# Altium schematic sheet NVC_Conn.SchDoc(NVC_Conn)
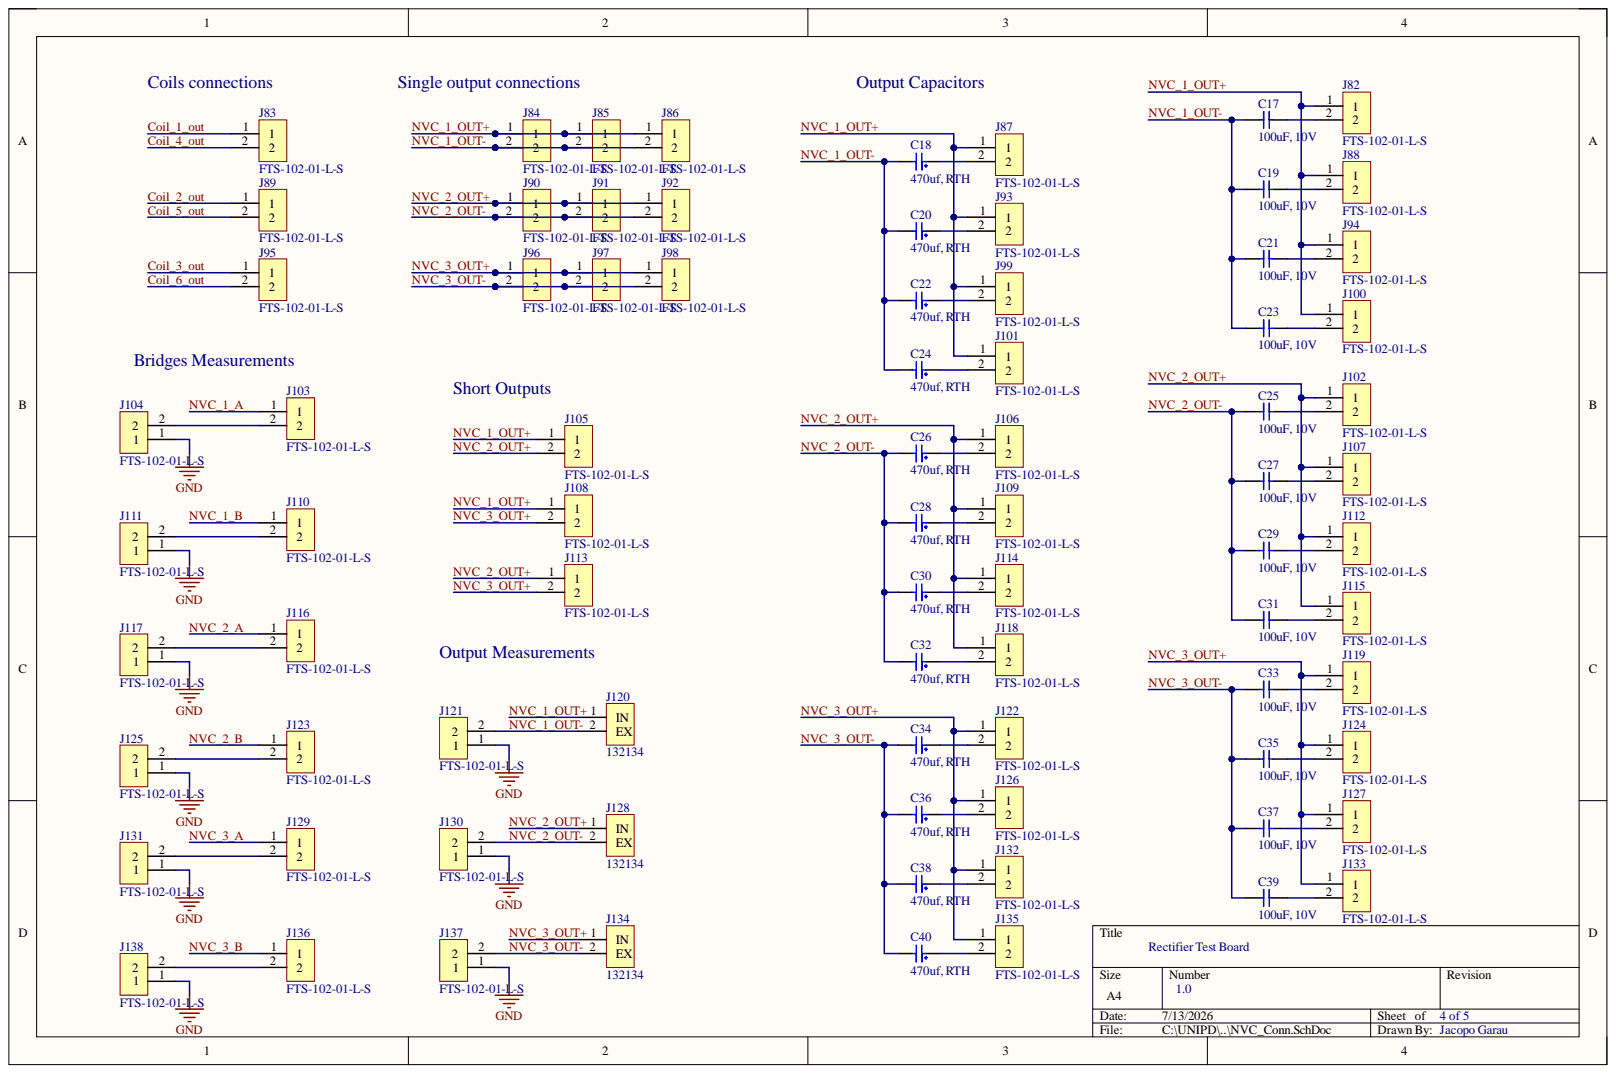
[diagram p0: NVC_Conn.SchDoc]

## Parts
C17  100uF, 10V  [CAP_1206]
C18  470uf, RTH  [CAP_YX_8X11P5_RUB]
C19  100uF, 10V  [CAP_1206]
C20  470uf, RTH  [CAP_YX_8X11P5_RUB]
C21  100uF, 10V  [CAP_1206]
C22  470uf, RTH  [CAP_YX_8X11P5_RUB]
C23  100uF, 10V  [CAP_1206]
C24  470uf, RTH  [CAP_YX_8X11P5_RUB]
C25  100uF, 10V  [CAP_1206]
C26  470uf, RTH  [CAP_YX_8X11P5_RUB]
C27  100uF, 10V  [CAP_1206]
C28  470uf, RTH  [CAP_YX_8X11P5_RUB]
C29  100uF, 10V  [CAP_1206]
C30  470uf, RTH  [CAP_YX_8X11P5_RUB]
C31  100uF, 10V  [CAP_1206]
C32  470uf, RTH  [CAP_YX_8X11P5_RUB]
C33  100uF, 10V  [CAP_1206]
C34  470uf, RTH  [CAP_YX_8X11P5_RUB]
C35  100uF, 10V  [CAP_1206]
C36  470uf, RTH  [CAP_YX_8X11P5_RUB]
C37  100uF, 10V  [CAP_1206]
C38  470uf, RTH  [CAP_YX_8X11P5_RUB]
C39  100uF, 10V  [CAP_1206]
C40  470uf, RTH  [CAP_YX_8X11P5_RUB]
J82  FTS-102-01-L-S  [HDR_1x2_1.27mm]
J83  FTS-102-01-L-S  [HDR_1x2_1.27mm]
J84  FTS-102-01-L-S  [HDR_1x2_1.27mm]
J85  FTS-102-01-L-S  [HDR_1x2_1.27mm]
J86  FTS-102-01-L-S  [HDR_1x2_1.27mm]
J87  FTS-102-01-L-S  [HDR_1x2_1.27mm]
J88  FTS-102-01-L-S  [HDR_1x2_1.27mm]
J89  FTS-102-01-L-S  [HDR_1x2_1.27mm]
J90  FTS-102-01-L-S  [HDR_1x2_1.27mm]
J91  FTS-102-01-L-S  [HDR_1x2_1.27mm]
J92  FTS-102-01-L-S  [HDR_1x2_1.27mm]
J93  FTS-102-01-L-S  [HDR_1x2_1.27mm]
J94  FTS-102-01-L-S  [HDR_1x2_1.27mm]
J95  FTS-102-01-L-S  [HDR_1x2_1.27mm]
J96  FTS-102-01-L-S  [HDR_1x2_1.27mm]
J97  FTS-102-01-L-S  [HDR_1x2_1.27mm]
J98  FTS-102-01-L-S  [HDR_1x2_1.27mm]
J99  FTS-102-01-L-S  [HDR_1x2_1.27mm]
J100  FTS-102-01-L-S  [HDR_1x2_1.27mm]
J101  FTS-102-01-L-S  [HDR_1x2_1.27mm]
J102  FTS-102-01-L-S  [HDR_1x2_1.27mm]
J103  FTS-102-01-L-S  [HDR_1x2_1.27mm]
J104  FTS-102-01-L-S  [HDR_1x2_1.27mm]
J105  FTS-102-01-L-S  [HDR_1x2_1.27mm]
J106  FTS-102-01-L-S  [HDR_1x2_1.27mm]
J107  FTS-102-01-L-S  [HDR_1x2_1.27mm]
J108  FTS-102-01-L-S  [HDR_1x2_1.27mm]
J109  FTS-102-01-L-S  [HDR_1x2_1.27mm]
J110  FTS-102-01-L-S  [HDR_1x2_1.27mm]
J111  FTS-102-01-L-S  [HDR_1x2_1.27mm]
J112  FTS-102-01-L-S  [HDR_1x2_1.27mm]
J113  FTS-102-01-L-S  [HDR_1x2_1.27mm]
J114  FTS-102-01-L-S  [HDR_1x2_1.27mm]
J115  FTS-102-01-L-S  [HDR_1x2_1.27mm]
J116  FTS-102-01-L-S  [HDR_1x2_1.27mm]
J117  FTS-102-01-L-S  [HDR_1x2_1.27mm]
J118  FTS-102-01-L-S  [HDR_1x2_1.27mm]
J119  FTS-102-01-L-S  [HDR_1x2_1.27mm]
J120  132134  [AMPHENOL_132134]
J121  FTS-102-01-L-S  [HDR_1x2_1.27mm]
J122  FTS-102-01-L-S  [HDR_1x2_1.27mm]
J123  FTS-102-01-L-S  [HDR_1x2_1.27mm]
J124  FTS-102-01-L-S  [HDR_1x2_1.27mm]
J125  FTS-102-01-L-S  [HDR_1x2_1.27mm]
J126  FTS-102-01-L-S  [HDR_1x2_1.27mm]
J127  FTS-102-01-L-S  [HDR_1x2_1.27mm]
J128  132134  [AMPHENOL_132134]
J129  FTS-102-01-L-S  [HDR_1x2_1.27mm]
J130  FTS-102-01-L-S  [HDR_1x2_1.27mm]
J131  FTS-102-01-L-S  [HDR_1x2_1.27mm]
J132  FTS-102-01-L-S  [HDR_1x2_1.27mm]
J133  FTS-102-01-L-S  [HDR_1x2_1.27mm]
J134  132134  [AMPHENOL_132134]
J135  FTS-102-01-L-S  [HDR_1x2_1.27mm]
J136  FTS-102-01-L-S  [HDR_1x2_1.27mm]
J137  FTS-102-01-L-S  [HDR_1x2_1.27mm]
J138  FTS-102-01-L-S  [HDR_1x2_1.27mm]

## Nets
GND: J104.1 J111.1 J117.1 J121.1 J125.1 J130.1 J131.1 J137.1 J138.1
Coil_1_out: J83.1
Coil_2_out: J89.1
Coil_3_out: J95.1
Coil_4_out: J83.2
Coil_5_out: J89.2
Coil_6_out: J95.2
NVC_1_A: J103.1
NVC_1_B: J110.1
NVC_1_OUT+: J82.1 J84.1 J85.1 J86.1 J87.1 J88.1 J93.1 J94.1 J99.1 J100.1 J101.1 J105.1 J108.1 J120.1
NVC_1_OUT-: C17.1 C18.2 C19.1 C20.2 C21.1 C22.2 C23.1 C24.2 J84.2 J85.2 J86.2 J120.2 J121.2
NVC_2_A: J116.1
NVC_2_B: J123.1
NVC_2_OUT+: J90.1 J91.1 J92.1 J102.1 J105.2 J106.1 J107.1 J109.1 J112.1 J113.1 J114.1 J115.1 J118.1 J128.1
NVC_2_OUT-: C25.1 C26.2 C27.1 C28.2 C29.1 C30.2 C31.1 C32.2 J90.2 J91.2 J92.2 J128.2 J130.2
NVC_3_A: J129.1
NVC_3_B: J136.1
NVC_3_OUT+: J96.1 J97.1 J98.1 J108.2 J113.2 J119.1 J122.1 J124.1 J126.1 J127.1 J132.1 J133.1 J134.1 J135.1
NVC_3_OUT-: C33.1 C34.2 C35.1 C36.2 C37.1 C38.2 C39.1 C40.2 J96.2 J97.2 J98.2 J134.2 J137.2
NetC17_2: C17.2 J82.2
NetC18_1: C18.1 J87.2
NetC19_2: C19.2 J88.2
NetC20_1: C20.1 J93.2
NetC21_2: C21.2 J94.2
NetC22_1: C22.1 J99.2
NetC23_2: C23.2 J100.2
NetC24_1: C24.1 J101.2
NetC25_2: C25.2 J102.2
NetC26_1: C26.1 J106.2
NetC27_2: C27.2 J107.2
NetC28_1: C28.1 J109.2
NetC29_2: C29.2 J112.2
NetC30_1: C30.1 J114.2
NetC31_2: C31.2 J115.2
NetC32_1: C32.1 J118.2
NetC33_2: C33.2 J119.2
NetC34_1: C34.1 J122.2
NetC35_2: C35.2 J124.2
NetC36_1: C36.1 J126.2
NetC37_2: C37.2 J127.2
NetC38_1: C38.1 J132.2
NetC39_2: C39.2 J133.2
NetC40_1: C40.1 J135.2
NetJ103_2: J103.2 J104.2
NetJ110_2: J110.2 J111.2
NetJ116_2: J116.2 J117.2
NetJ123_2: J123.2 J125.2
NetJ129_2: J129.2 J131.2
NetJ136_2: J136.2 J138.2

## Library data of the parts
C17 C19 C21 C23 C25 C27 C29 C31 C33 C35 C37 C39 = GRM31CR61A107MEA8L: 10V 100uF X5R ±20% 1206 Multilayer Ceramic Capacitors MLCC - SMD/SMT ROHS; Manufacturer: Murata; Manufacturer Lifecycle Status: IN PRODUCTION; Value: 100µF; Case/Package: 1206; Category: Ceramic Capacitors; Component Kind: Standard; ComponentLink1Description: https://datasheet.octopart.com:443/GRM31CR61A107MEA8L-Murata-datasheet-165579666.pdf?src-supplier=TME; ComponentLink1URL: https://datasheet.octopart.com:443/GRM31CR61A107MEA8L-Murata-datasheet-165579666.pdf?src-supplier=TME; ComponentLink2Description: https://datasheet.octopart.com/GRM31CR61A107MEA8L-Murata-datasheet-12515295.pdf; ComponentLink2URL: https://datasheet.octopart.com/GRM31CR61A107MEA8L-Murata-datasheet-12515295.pdf; ComponentLink3Description: https://datasheet.octopart.com/GRM31CR61A107MEA8L-Murata-datasheet-12510076.pdf; ComponentLink3URL: https://datasheet.octopart.com/GRM31CR61A107MEA8L-Murata-datasheet-12510076.pdf; ComponentLink4Description: https://datasheet.octopart.com/GRM31CR61A107MEA8L-Murata-datasheet-11978611.pdf; ComponentLink4URL: https://datasheet.octopart.com/GRM31CR61A107MEA8L-Murata-datasheet-11978611.pdf; ComponentLink5Description: https://datasheet.octopart.com/GRM31CR61A107MEA8L-Murata-datasheet-10025737.pdf; ComponentLink5URL: https://datasheet.octopart.com/GRM31CR61A107MEA8L-Murata-datasheet-10025737.pdf; ComponentLink6Description: https://datasheet.octopart.com/GRM31CR61A107MEA8L-Murata-datasheet-8819587.pdf; ComponentLink6URL: https://datasheet.octopart.com/GRM31CR61A107MEA8L-Murata-datasheet-8819587.pdf; ComponentLink7Description: http://sigma.octopart.com:80/reach_statement/Murata-company-16.pdf?src-supplier=Compliance+Statements; ComponentLink7URL: http://sigma.octopart.com:80/reach_statement/Murata-company-16.pdf?src-supplier=Compliance+Statements; Dielectric: X5R; Dielectric Material: Ceramic; Export Control Classification Number (ECCN) Code: EAR99; Harmonized Tariff Schedule (HTS) Code: 8532.24.00.20; Height: 1.6mm; Introduction Date: 2020-12-28; Length: 3.2mm; Library Name: My_Integrated_Library.IntLib; Lifecycle Status: Production; Manufacturer Part Number: GRM31CR61A107MEA8L; Material: Ceramic; Max Operating Temperature: 85°C; Min Operating Temperature: -55°C; Mounting Technology: Surface Mount; Number of Terminals: 2; Pricing: 1=0.49, 10=0.3, 50=0.2244, 100=0.2009, 500=0.16148, 1000=0.14931 (USD); REACH SVHC: Yes; RoHS: Compliant; Stock: 193494; Supplier: DigiKey; Supplier Part Number: 490-GRM31CR61A107MEA8LDKR-ND; Thickness: 1.6mm; Tolerance: 20%; Voltage Rating: 10V; Voltage Rating (DC): 10V; Width: 1.6mm
C18 C20 C22 C24 C26 C28 C30 C32 C34 C36 C38 C40 = 16ZLH470MEFCT78X11.5: Aluminum Electrolytic Capacitor, Polarized, Aluminum (wet), 16V, 20% +Tol, 20% -Tol, 470uF, Through Hole Mount; Manufacturer: Rubycon; Impedance: 56mΩ; Leakage Current: 75.2µA; Life (Hours): 8000hours; Ripple Current: 519.75mA; Value: 470µF; Case/Package: Radial; Category: Aluminum Electrolytic Capacitors; Component Kind: Standard; ComponentLink1Description: https://datasheet.octopart.com:443/16ZLH470MEFCT78X11.5-Rubycon-datasheet-154841597.pdf?src-supplier=IHS; ComponentLink1URL: https://datasheet.octopart.com:443/16ZLH470MEFCT78X11.5-Rubycon-datasheet-154841597.pdf?src-supplier=IHS; ComponentLink2Description: https://datasheet.octopart.com:443/16ZLH470MEFCT78X11.5-Rubycon-datasheet-86451566.pdf?src-supplier=Electro+Sonic; ComponentLink2URL: https://datasheet.octopart.com:443/16ZLH470MEFCT78X11.5-Rubycon-datasheet-86451566.pdf?src-supplier=Electro+Sonic; Country of Origin: Japan; Diameter: 8mm; Export Control Classification Number (ECCN) Code: EAR99; Harmonized Tariff Schedule (HTS) Code: 8532.22.00.20; Height - Seated (Max): 13.0048mm; Introduction Date: 2010-09-20; Lead Pitch: 3.5052mm; Length: 11.5mm; Library Name: MySchLib.SchLib; Lifecycle Status: Production; Manufacturer Part Number: 16ZLH470MEFCT78X11.5; Max Operating Temperature: 105°C; Min Operating Temperature: -40°C; Mounting Technology: Through Hole; Number of Terminals: 2; Packaging: Tape & Box (TB); Pricing: 1=0.45, 10=0.28, 50=0.2056, 100=0.1821, 500=0.14146 (USD); REACH SVHC: Yes; RoHS: Compliant; Stock: 21478; Supplier: DigiKey; Supplier Part Number: 1189-3712-1-ND; Terminal Pitch: 3.5mm; Tolerance: 20%; Voltage Rating: 16V; Voltage Rating (DC): 16V
J82-J119 J121-J127 J129-J133 J135-J138 = FTS-102-01-L-S: CONN HEADER VERT 2POS 1.27MM; Manufacturer: Samtec; Manufacturer Lifecycle Status: PRODUCTION; Gender: Male; Number of Contacts: 2; Category: Headers and Wire Housings; Component Kind: Standard; ComponentLink1Description: https://sigma.octopart.com:443/165596534/technical_drawing/Samtec-FTS-102-01-L-S.pdf?src-supplier=JRH+Electronics; ComponentLink1URL: https://sigma.octopart.com:443/165596534/technical_drawing/Samtec-FTS-102-01-L-S.pdf?src-supplier=JRH+Electronics; ComponentLink2Description: https://datasheet.octopart.com:443/FTS-102-01-L-S-Samtec-datasheet-164323441.pdf?src-supplier=Upverter; ComponentLink2URL: https://datasheet.octopart.com:443/FTS-102-01-L-S-Samtec-datasheet-164323441.pdf?src-supplier=Upverter; ComponentLink3Description: https://sigma.octopart.com:443/97029285/technical_drawing/Samtec-FTS-102-01-L-S.pdf?src-supplier=Newark; ComponentLink3URL: https://sigma.octopart.com:443/97029285/technical_drawing/Samtec-FTS-102-01-L-S.pdf?src-supplier=Newark; ComponentLink4Description: https://datasheet.octopart.com:443/FTS-102-01-L-S-Samtec-datasheet-97029283.pdf?src-supplier=Samtec; ComponentLink4URL: https://datasheet.octopart.com:443/FTS-102-01-L-S-Samtec-datasheet-97029283.pdf?src-supplier=Samtec; ComponentLink5Description: https://datasheet.octopart.com:443/FTS-102-01-L-S-Samtec-datasheet-118493358.pdf?src-supplier=Electro+Sonic; ComponentLink5URL: https://datasheet.octopart.com:443/FTS-102-01-L-S-Samtec-datasheet-118493358.pdf?src-supplier=Electro+Sonic; ComponentLink6Description: https://datasheet.octopart.com:443/FTS-102-01-L-S-Samtec-datasheet-176663378.pdf?src-supplier=Toby+Electronics; ComponentLink6URL: https://datasheet.octopart.com:443/FTS-102-01-L-S-Samtec-datasheet-176663378.pdf?src-supplier=Toby+Electronics; ComponentLink7Description: https://datasheet.octopart.com:443/FTS-102-01-L-S-Samtec-datasheet-116535195.pdf?src-supplier=Toby+Electronics; ComponentLink7URL: https://datasheet.octopart.com:443/FTS-102-01-L-S-Samtec-datasheet-116535195.pdf?src-supplier=Toby+Electronics; ComponentLink8Description: https://datasheet.octopart.com:443/FTS-102-01-L-S-Samtec-datasheet-136395376.pdf?src-supplier=Toby+Electronics; ComponentLink8URL: https://datasheet.octopart.com:443/FTS-102-01-L-S-Samtec-datasheet-136395376.pdf?src-supplier=Toby+Electronics; ComponentLink9Description: https://datasheet.octopart.com:443/FTS-102-01-L-S-Samtec-datasheet-151470456.pdf?src-supplier=Sager+Electronics; ComponentLink9URL: https://datasheet.octopart.com:443/FTS-102-01-L-S-Samtec-datasheet-151470456.pdf?src-supplier=Sager+Electronics; Contact Material: Gold, Nickel; Contact Plating: Gold; Height: 4.17mm; Housing Color: Black; Housing Material: Polymer; Length: 2.54mm; Library Name: Schlib.SchLib; Lifecycle Status: Production; Manufacturer Part Number: FTS-102-01-L-S; Max Operating Temperature: 125°C; Min Operating Temperature: -55°C; Number of Rows: 2; Orientation: Straight; Packaging: Bulk; Pitch: 1.27mm; Pricing: 1=0.57, 50=0.496, 100=0.42, 500=0.35, 1000=0.299, 2000=0.244, 5000=0.24223 (USD); Radiation Hardening: No; RoHS: Compliant; Stock: 1509; Supplier: DigiKey; Supplier Part Number: FTS-102-01-L-S-ND; Termination: Solder
J120 J128 J134 = 132134: connector, rf coaxial, sma str jack for p.c.board, 4 legs, .050 center cont, 50 ohm; Manufacturer: Amphenol RF; Body Material: Brass; Body Plating: Gold; Clock Frequency: 18GHz; Connector Type: Coaxial, SMA, Socket; Dielectric Material: PTFE; Impedance: 50Ω; Number of Contacts: 1; Case/Package: SMA; Category: RF / Coaxial Connectors; Component Kind: Standard; ComponentLink1Description: https://datasheet.octopart.com:443/132134-Amphenol-RF-datasheet-178922999.pdf?src-supplier=ODG+(Origin+Data+Global); ComponentLink1URL: https://datasheet.octopart.com:443/132134-Amphenol-RF-datasheet-178922999.pdf?src-supplier=ODG+(Origin+Data+Global); ComponentLink2Description: https://datasheet.octopart.com:443/132134-Amphenol-RF-datasheet-11478678.pdf?src-supplier=TME; ComponentLink2URL: https://datasheet.octopart.com:443/132134-Amphenol-RF-datasheet-11478678.pdf?src-supplier=TME; ComponentLink3Description: https://datasheet.octopart.com:443/132134-Amphenol-RF-datasheet-21190506.pdf?src-supplier=element14+APAC; ComponentLink3URL: https://datasheet.octopart.com:443/132134-Amphenol-RF-datasheet-21190506.pdf?src-supplier=element14+APAC; ComponentLink4Description: https://datasheet.octopart.com:443/132134-Amphenol-datasheet-8508134.pdf?src-supplier=element14+APAC; ComponentLink4URL: https://datasheet.octopart.com:443/132134-Amphenol-datasheet-8508134.pdf?src-supplier=element14+APAC; ComponentLink5Description: https://datasheet.octopart.com:443/132134-Amphenol-RF-datasheet-48840.pdf?src-supplier=RS+(Formerly+Allied+Electronics); ComponentLink5URL: https://datasheet.octopart.com:443/132134-Amphenol-RF-datasheet-48840.pdf?src-supplier=RS+(Formerly+Allied+Electronics); ComponentLink6Description: https://datasheet.octopart.com:443/132134-Amphenol-datasheet-16831.pdf?src-supplier=DigiKey; ComponentLink6URL: https://datasheet.octopart.com:443/132134-Amphenol-datasheet-16831.pdf?src-supplier=DigiKey; ComponentLink7Description: https://datasheet.octopart.com:443/132134-Amphenol-Connex-datasheet-31974814.pdf?src-supplier=East+Coast+Microwave; ComponentLink7URL: https://datasheet.octopart.com:443/132134-Amphenol-Connex-datasheet-31974814.pdf?src-supplier=East+Coast+Microwave; ComponentLink8Description: https://datasheet.octopart.com:443/132134-Amphenol-Connex-datasheet-32030771.pdf?src-supplier=East+Coast+Microwave; ComponentLink8URL: https://datasheet.octopart.com:443/132134-Amphenol-Connex-datasheet-32030771.pdf?src-supplier=East+Coast+Microwave; ComponentLink9Description: https://datasheet.octopart.com:443/132134-Amphenol-RF-datasheet-177010530.pdf?src-supplier=Burklin+Elektronik; ComponentLink9URL: https://datasheet.octopart.com:443/132134-Amphenol-RF-datasheet-177010530.pdf?src-supplier=Burklin+Elektronik; ComponentLink10Description: https://datasheet.octopart.com:443/132134-Amphenol-datasheet-7088102.pdf?src-supplier=Jameco; ComponentLink10URL: https://datasheet.octopart.com:443/132134-Amphenol-datasheet-7088102.pdf?src-supplier=Jameco; Contact Gender: Female; Contact Material: Gold; Contact Plating: Gold; Country of Origin: Vietnam; Depth: 7mm; Export Control Classification Number (ECCN) Code: EAR99; Fastening Type: Threaded; Frequency: 18GHz; Harmonized Tariff Schedule (HTS) Code: 8536.69.40.10; Height: 9.73mm; Housing Color: Gold; Insulation Material: Teflon; Introduction Date: 2001-11-27; Lead Free: Lead Free; Length: 7mm; Library Name: Schlib.SchLib; Lifecycle Status: Production; Manufacturer Part Number: 132134; Max Operating Temperature: 165°C; Min Operating Temperature: -65°C; Mounting Technology: PCB, Through Hole; Number of Ports: 1; Orientation: Straight; Packaging: Bulk; Pins: 1; Pricing: 1=6.97, 10=5.922, 25=5.5508, 50=5.2856, 100=5.0333, 300=4.65793, 500=4.49316, 1000=4.27889 (USD); Radiation Hardening: No; REACH SVHC: No; RoHS: Compliant; Shell Material: Brass; Stock: 22451; Supplier: DigiKey; Supplier Part Number: ACX1230-ND; Termination: Solder; Weight: 1.000001g
